# Altium schematic sheet Error_Generator.SchDoc(Error_Generator)
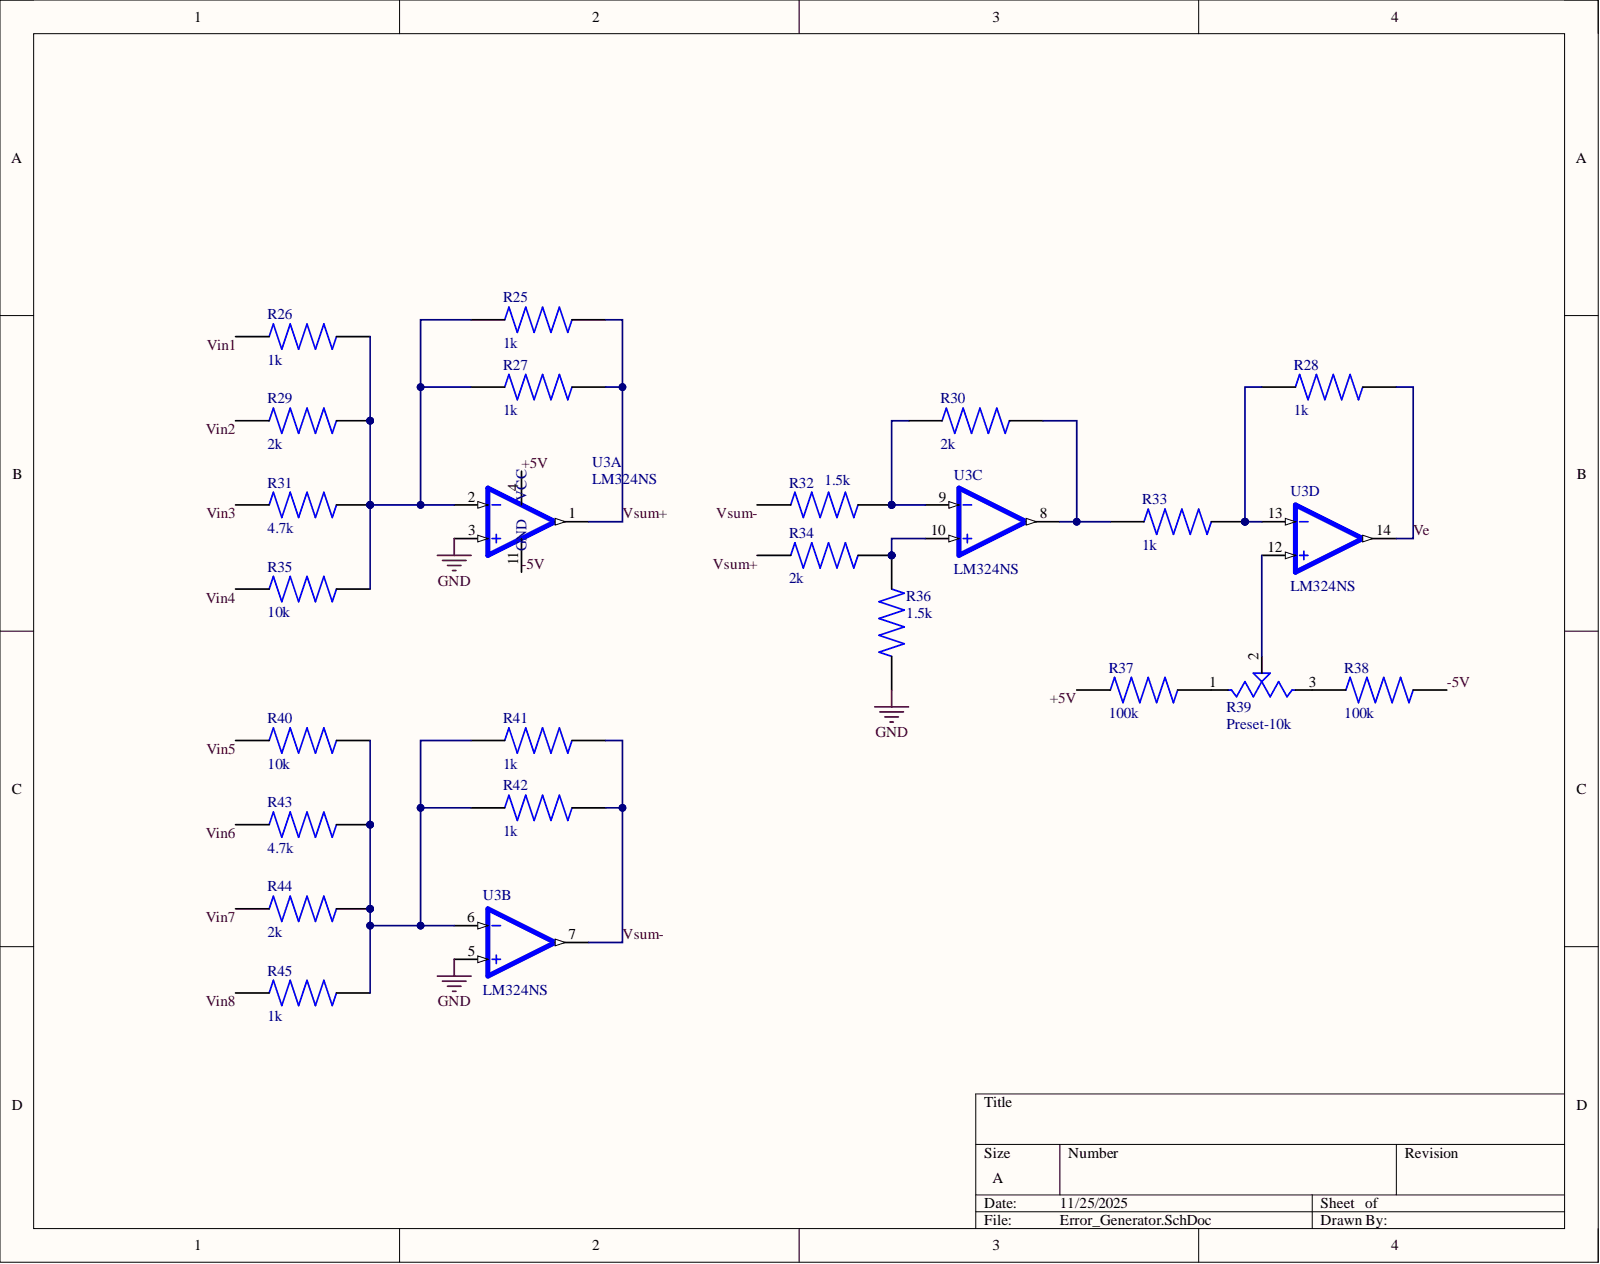
[diagram p0: Error_Generator.SchDoc]

## Parts
R25  1k
R26  1k
R27  1k
R28  1k
R29  2k
R30  2k
R31  4.7k
R32  1.5k
R33  1k
R34  2k
R35  10k
R36  1.5k
R37  100k
R38  100k
R39  Preset-10k
R40  10k
R41  1k
R42  1k
R43  4.7k
R44  2k
R45  1k
U3  LM324NS  (parts A,B,C,D)

## Nets
GND: R36.1 U3.3 U3.5
+5V: R37.1 U3.4
-5V: R38.2 U3.11
Ve: R28.2 U3.14
Vin1: R26.1
Vin2: R29.1
Vin3: R31.1
Vin4: R35.1
Vin5: R40.1
Vin6: R43.1
Vin7: R44.1
Vin8: R45.1
Vsum+: R25.2 R27.2 R34.1 U3.1
Vsum-: R32.1 R41.2 R42.2 U3.7
NetR25_1: R25.1 R26.2 R27.1 R29.2 R31.2 R35.2 U3.2
NetR28_1: R28.1 R33.2 U3.13
NetR30_1: R30.1 R32.2 U3.9
NetR30_2: R30.2 R33.1 U3.8
NetR34_2: R34.2 R36.2 U3.10
NetR37_2: R37.2 R39.1
NetR38_1: R38.1 R39.3
NetR39_2: R39.2 U3.12
NetR40_2: R40.2 R41.1 R42.1 R43.2 R44.2 R45.2 U3.6

## Library data of the parts
R25-R28 R33 R41 R42 R45 = 1k: 1 kOhms Â±1% 0.125W, 1/8W Chip Resistor 0805 (2012 Metric) Moisture Resistant Thick Film; RC0805FR-071KL; MF: Yageo; Check_prices: https://www.snapeda.com/parts/RC0805FR-071KL/Yageo/view-part/?ref=eda; Component Kind: Standard; DigiKey_Part_Number: 311-1.00KCRTR-ND; Library Name: 1ks.IntLib; MP: RC0805FR-071KL; Package: 2012 Yageo; Purchase-URL: https://pricing.snapeda.com/search?q=RC0805FR-071KL&ref=eda; SnapEDA_Link: https://www.snapeda.com/parts/RC0805FR-071KL/Yageo/view-part/?ref=snap
R29 R30 R34 R44 = 2k: 2 kOhms Â±1% 0.125W, 1/8W Chip Resistor 0805 (2012 Metric) Moisture Resistant Thick Film; RC0805FR-072KL; MF: Yageo; Check_prices: https://www.snapeda.com/parts/RC0805FR-072KL/Yageo/view-part/?ref=eda; Component Kind: Standard; Library Name: 2ks.IntLib; MP: RC0805FR-072KL; Package: 2012 Yageo; SnapEDA_Link: https://www.snapeda.com/parts/RC0805FR-072KL/Yageo/view-part/?ref=snap
R31 R43 = 4.7k: 4.7 kOhms Â±1% 0.125W, 1/8W Chip Resistor 0805 (2012 Metric) Moisture Resistant Thick Film; RC0805FR-074K7L; MF: Yageo; Availability: In Stock; Check_prices: https://www.snapeda.com/parts/RC0805FR-074K7L/Yageo/view-part/?ref=eda; Component Kind: Standard; Library Name: 4 7ks.IntLib; MP: RC0805FR-074K7L; Package: 2012 Rohm Semiconductor; Price: None; Purchase-URL: https://pricing.snapeda.com/search/part/RC0805FR-074K7L/?ref=eda; SnapEDA_Link: https://www.snapeda.com/parts/RC0805FR-074K7L/Yageo/view-part/?ref=snap
R32 R36 = 1.5k: Res Thin Film 0805 1.5K Ohm 0.1% 0.125W(1/8W) Â±25ppm/C Epoxy Pad SMD T/R; RT0805BRD071K5L; MF: Yageo; Check_prices: https://www.snapeda.com/parts/RT0805BRD071K5L/Yageo/view-part/?ref=eda; Component Kind: Standard; Library Name: 1 5ks.IntLib; MP: RT0805BRD071K5L; Package: 2012 Yageo; SnapEDA_Link: https://www.snapeda.com/parts/RT0805BRD071K5L/Yageo/view-part/?ref=snap
R35 R40 = 10k: 10 kOhms Â±1% 0.125W, 1/8W Chip Resistor 0805 (2012 Metric) Moisture Resistant Thick Film; RC0805FR-0710KL; MF: Yageo; Availability: In Stock; Check_prices: https://www.snapeda.com/parts/RC0805FR-0710KL/Yageo/view-part/?ref=eda; Component Kind: Standard; Library Name: 10ks.IntLib; MP: RC0805FR-0710KL; Package: 2012 Rohm Semiconductor; Price: None; Purchase-URL: https://pricing.snapeda.com/search/part/RC0805FR-0710KL/?ref=eda; SnapEDA_Link: https://www.snapeda.com/parts/RC0805FR-0710KL/Yageo/view-part/?ref=snap
R37 R38 = 100k: 100 kOhms Â±1% 0.125W, 1/8W Chip Resistor 0805 (2012 Metric) Moisture Resistant Thick Film; RC0805FR-07100KL; MF: Yageo; Check_prices: https://www.snapeda.com/parts/RC0805FR-07100KL/Yageo/view-part/?ref=eda; Component Kind: Standard; Library Name: 100ks.IntLib; MP: RC0805FR-07100KL; Package: 2012 Yageo; SnapEDA_Link: https://www.snapeda.com/parts/RC0805FR-07100KL/Yageo/view-part/?ref=snap
R39 = Preset: TRIMMER - 6MM ROUND, SINGLE-TURN,CERMET, 10K OHMS | Bourns 3306F-1-103; Check_prices: https://www.snapeda.com/parts/3306F-1-103/Bourns/view-part/?ref=eda; Component Kind: Standard; Library Name: 3306F-1-103.IntLib; MF: Bourns; MP: 3306F-1-103; Package: 3306F Bourns; SnapEDA_Link: https://www.snapeda.com/parts/3306F-1-103/Bourns/view-part/?ref=snap
U3 = LM324NS: 4-Channel industry standard operational amplifier 14-SO 0 to 70; LM324NSRG4; MF: Texas Instruments; Availability: In Stock; Check_prices: https://www.snapeda.com/parts/LM324NSRG4/Texas+Instruments/view-part/?ref=eda; Component Kind: Standard; Library Name: LM324NSRG4.IntLib; MP: LM324NSRG4; Package: SOP-14 Texas Instruments; Price: None; Purchase-URL: https://pricing.snapeda.com/search/part/LM324NSRG4/?ref=eda; SnapEDA_Link: https://www.snapeda.com/parts/LM324NSRG4/Texas+Instruments/view-part/?ref=snap
